# Altium schematic sheet Center Console - Connectors.SchDoc("Center Console - Connectors")
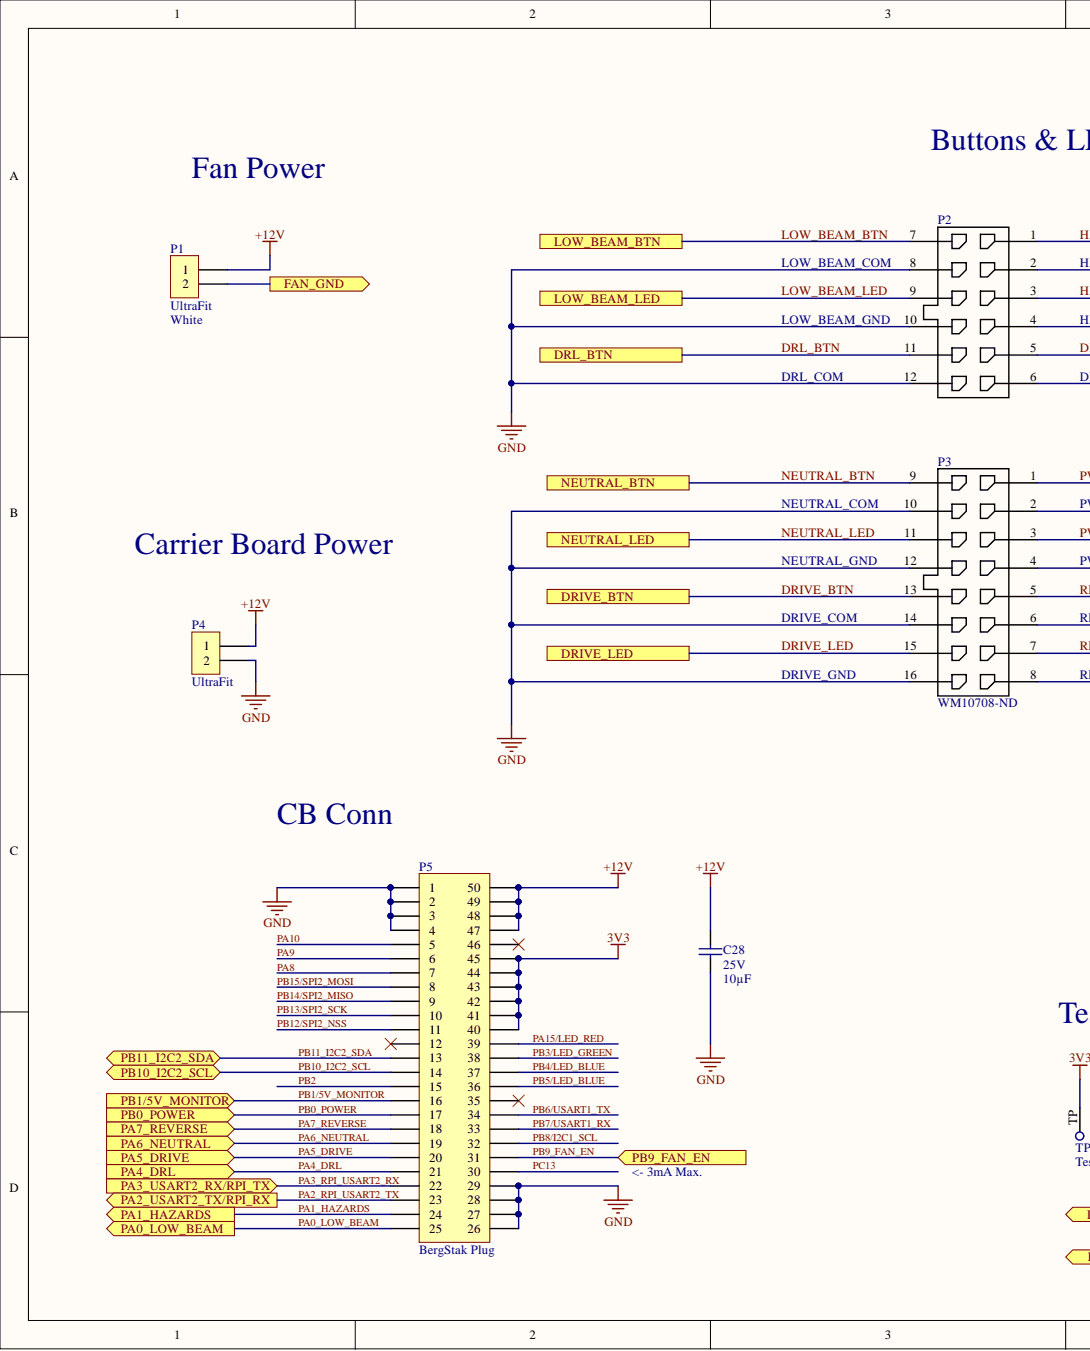
[diagram p0: Center Console - Connectors.SchDoc, left]
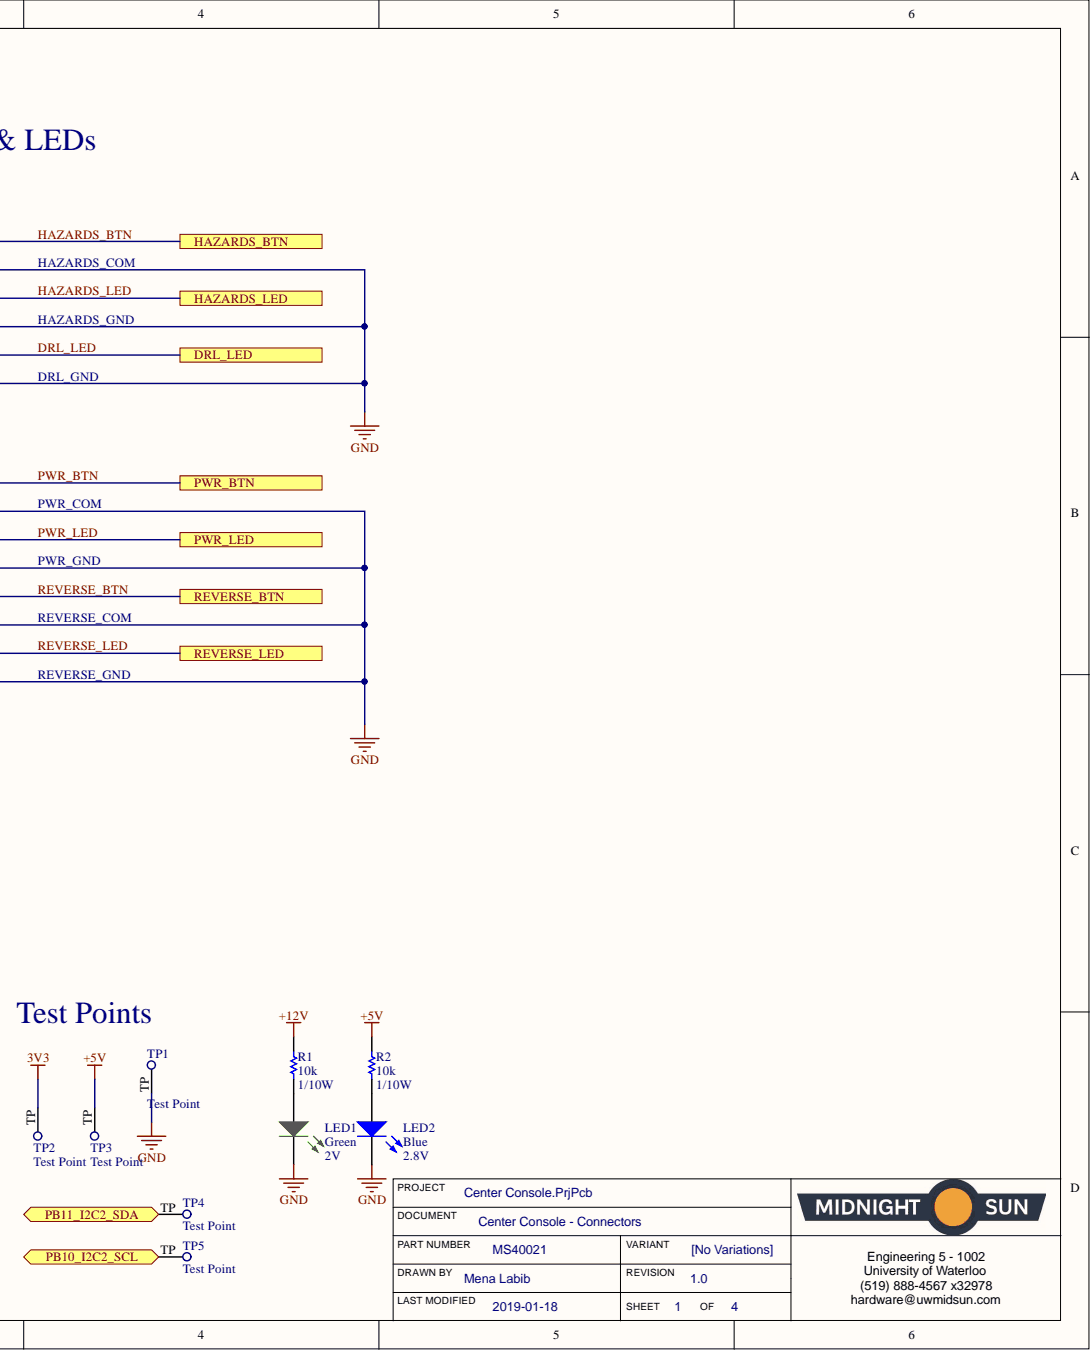
[diagram p1: Center Console - Connectors.SchDoc, right]

## Parts
C28  Voltage - Rated: 25V  Capacitance: 10µF  [CAP, 0805]  (hidden comment: CAP CER 10uF 25V 10% X5R 0805)
LED1  Voltage - Forward (Vf) (Typ): 2V  Color: Green  [LED_0603]  (hidden comment: LED GREEN CLEAR 2V 0603)
LED2  Voltage - Forward (Vf) (Typ): 2.8V  Color: Blue  [LED_0603]  (hidden comment: LED BLUE CLEAR 2.8V 0603)
P1  Series: UltraFit  Color: White  [CONN, 2POS ULTRA-FIT - NATURAL COLOR]  (hidden comment: CONN 2POS ULTRA-FIT NATURAL COLOR 0.138")
P2  CONN 12POS MICRO-FIT 3mm  [CONN, 12POS MICRO-FIT 3mm]  (value not drawn)
P3  Supplier Part Number 1: WM10708-ND  [CONN, 16POS MICRO-FIT 3MM]  (hidden comment: CONN 16POS MICRO-FIT 3mm)
P4  Series: UltraFit  [CONN, 2POS ULTRA-FIT]  (hidden comment: CONN 2POS ULTRA-FIT 0.138")
P5  Series: BergStak Plug  [CONN, Bergstak 50 Pos Plug]  (hidden comment: CONN 50POS Bergstak Plug 0.02")
R1  Resistance: 10k  Power: 1/10W  [RES, 0603]  (hidden comment: RES 10K OHM 1% 1/10W 0603)
R2  Resistance: 10k  Power: 1/10W  [RES, 0603]  (hidden comment: RES 10K OHM 1% 1/10W 0603)
TP1  Test Point  [Test Point]  (no BOM)
TP2  Test Point  [Test Point]  (no BOM)
TP3  Test Point  [Test Point]  (no BOM)
TP4  Test Point  [Test Point]  (no BOM)
TP5  Test Point  [Test Point]  (no BOM)

## Ports
DRIVE_BTN, DRIVE_LED, DRL_BTN, DRL_LED, FAN_GND, HAZARDS_BTN, HAZARDS_LED, LOW_BEAM_BTN, LOW_BEAM_LED, NEUTRAL_BTN, NEUTRAL_LED, PA0_LOW_BEAM, PA1_HAZARDS, PA2_USART2_TX/RPI_RX, PA3_USART2_RX/RPI_TX, PA4_DRL, PA5_DRIVE, PA6_NEUTRAL, PA7_REVERSE, PB0_POWER, PB1/5V_MONITOR, PB9_FAN_EN, PB10_I2C2_SCL, PB11_I2C2_SDA, PWR_BTN, PWR_LED, REVERSE_BTN, REVERSE_LED

## Nets
3V3: P5.40 P5.41 P5.42 P5.43 P5.44 P5.45 TP2.TP
+5V: R2.1 TP3.TP
+12V: C28.1 P1.1 P4.1 P5.47 P5.48 P5.49 P5.50 R1.1
GND: C28.2 LED1.2 LED2.2 P2.2 P2.4 P2.6 P2.8 P2.10 P2.12 P3.2 P3.4 P3.6 P3.8 P3.10 P3.12 P3.14 P3.16 P4.2 P5.1 P5.2 P5.3 P5.4 P5.26 P5.27 P5.28 P5.29 TP1.TP
DRIVE_BTN: P3.13 ; port DRIVE_BTN
DRIVE_LED: P3.15 ; port DRIVE_LED
DRL_BTN: P2.11 ; port DRL_BTN
DRL_LED: P2.5 ; port DRL_LED
FAN_GND: P1.2 ; port FAN_GND
HAZARDS_BTN: P2.1 ; port HAZARDS_BTN
HAZARDS_LED: P2.3 ; port HAZARDS_LED
LOW_BEAM_BTN: P2.7 ; port LOW_BEAM_BTN
LOW_BEAM_LED: P2.9 ; port LOW_BEAM_LED
NEUTRAL_BTN: P3.9 ; port NEUTRAL_BTN
NEUTRAL_LED: P3.11 ; port NEUTRAL_LED
PA0_LOW_BEAM: P5.25 ; port PA0_LOW_BEAM
PA1_HAZARDS: P5.24 ; port PA1_HAZARDS
PA2_RPI_USART2_TX: P5.23 ; port PA2_USART2_TX/RPI_RX
PA3_RPI_USART2_RX: P5.22 ; port PA3_USART2_RX/RPI_TX
PA4_DRL: P5.21 ; port PA4_DRL
PA5_DRIVE: P5.20 ; port PA5_DRIVE
PA6_NEUTRAL: P5.19 ; port PA6_NEUTRAL
PA7_REVERSE: P5.18 ; port PA7_REVERSE
PA8_1: P5.7  [also labelled PA8]
PA9_1: P5.6  [also labelled PA9]
PA10_1: P5.5  [also labelled PA10]
PA15/LED_RED_1: P5.39  [also labelled PA15/LED_RED]
PB0_POWER: P5.17 ; port PB0_POWER
PB1/5V_MONITOR: P5.16 ; port PB1/5V_MONITOR
PB2_1: P5.15  [also labelled PB2]
PB3/LED_GREEN_1: P5.38  [also labelled PB3/LED_GREEN]
PB4/LED_BLUE_1: P5.37  [also labelled PB4/LED_BLUE]
PB5/LED_BLUE_1: P5.36  [also labelled PB5/LED_BLUE]
PB6/USART1_TX_1: P5.34  [also labelled PB6/USART1_TX]
PB7/USART1_RX_1: P5.33  [also labelled PB7/USART1_RX]
PB8/I2C1_SCL_1: P5.32  [also labelled PB8/I2C1_SCL]
PB9_FAN_EN: P5.31 ; port PB9_FAN_EN
PB10_I2C2_SCL: P5.14 TP5.TP ; port PB10_I2C2_SCL
PB11_I2C2_SDA: P5.13 TP4.TP ; port PB11_I2C2_SDA
PB12/SPI2_NSS_1: P5.11  [also labelled PB12/SPI2_NSS]
PB13/SPI2_SCK_1: P5.10  [also labelled PB13/SPI2_SCK]
PB14/SPI2_MISO_1: P5.9  [also labelled PB14/SPI2_MISO]
PB15/SPI2_MOSI_1: P5.8  [also labelled PB15/SPI2_MOSI]
PC13_1: P5.30  [also labelled PC13]
PWR_BTN: P3.1 ; port PWR_BTN
PWR_LED: P3.3 ; port PWR_LED
REVERSE_BTN: P3.5 ; port REVERSE_BTN
REVERSE_LED: P3.7 ; port REVERSE_LED
NetLED1_1: LED1.1 R1.2
NetLED2_1: LED2.1 R2.2
NetP5_12: P5.12
NetP5_35: P5.35
NetP5_46: P5.46

## Library data of the parts
C28 = CAP CER 10uF 25V 10% X5R 0805: 10µF ±10% 25V Ceramic Capacitor X5R 0805 (2012 Metric); Manufacturer Part Number: GRM21BR61E106KA73L; Manufacturer: Murata Electronics North America; Tolerance: ±10%; Thickness (Max): 0.053" (1.35mm); Temperature Coefficient: X5R; Supplier Part Number 1: 490-5523-1-ND; Supplier 1: Digi-Key; Stock 1: 1695157; Size / Dimension: 0.079" L x 0.049" W (2.00mm x 1.25mm); RoHS: RoHS Compliant; Ratings: -; Pricing 1: 1=0.3, 10=0.214, 100=0.1008, 500=0.07068, 1000=0.05851 (CAD); Part Status: Active; Packaging: Cut Tape (CT); Package / Case: 0805 (2012 Metric); Operating Temperature: -55°C ~ 85°C; Mounting Type: Surface Mount, MLCC; Lead Style: -; Lead Spacing: -; Height - Seated (Max): -; Features: -; ComponentLink1URL: http://search.murata.co.jp/Ceramy/image/img/A01X/G101/ENG/GRM21BR61E106KA73-01.pdf; ComponentLink1Description: http://search.murata.co.jp/Ceramy/image/img/A01X/G101/ENG/GRM21BR61E106KA73-01.pdf; Component Kind: Standard; Category: Capacitors; Applications: General Purpose
LED1 = LED GREEN CLEAR 2V 0603: Green 572nm LED Indication - Discrete 2V 0603 (1608 Metric); Manufacturer Part Number: 150060VS75000; Manufacturer: Wurth Electronics Inc.; Wavelength - Dominant: 570nm; Viewing Angle: 140°; Millicandela Rating: 40mcd; Lens Style/Size: Rectangle with Flat Top, 1.10mm x 0.70mm; Lens Color: Colorless; Current - Test: 20mA; Wavelength - Peak: 572nm; Supplier Part Number 1: 732-4980-1-ND; Supplier Device Package: 0603; Supplier 1: Digi-Key; Stock 1: 9408; Size / Dimension: 1.60mm L x 0.80mm W; RoHS: RoHS Compliant; Pricing 1: 1=0.21, 100=0.1617, 500=0.13964 (CAD); Part Status: Active; Packaging: Cut Tape (CT); Package / Case: 0603 (1608 Metric); Mounting Type: Surface Mount; Lens Transparency: Clear; Height (Max): 0.80mm; Features: -; ComponentLink1URL: http://katalog.we-online.de/led/datasheet/150060VS75000.pdf; ComponentLink1Description: http://katalog.we-online.de/led/datasheet/150060VS75000.pdf; Component Kind: Standard; Category: Optoelectronics; Library Name: Schematic Diagrams.SchLib
LED2 = LED BLUE CLEAR 2.8V 0603: Blue 470nm LED Indication - Discrete 2.8V 0603 (1608 Metric); Manufacturer Part Number 1: LTST-C193TBKT-5A; Manufacturer 1: Lite-On Inc.; Wavelength - Peak: 468nm; Viewing Angle: 130°; Millicandela Rating: 15mcd; Lens Style/Size: Rectangle with Flat Top, 1.15mm x 0.80mm; Lens Color: Colorless; Current - Test: 5mA; Wavelength - Dominant: 470nm; Supplier Part Number 1: 160-1827-1-ND; Supplier Device Package: Chip LED; Supplier 1: Digi-Key; Size / Dimension: 1.60mm L x 0.80mm W; Part Status: Active; Packaging: Cut Tape (CT); Package / Case: 0603 (1608 Metric); Mounting Type: Surface Mount; Lens Transparency: Clear; Height (Max): 0.45mm; ComponentLink1URL: http://optoelectronics.liteon.com/upload/download/DS22-2004-060/P_100_LTST-C193TBKT-5A.pdf; ComponentLink1Description: http://optoelectronics.liteon.com/upload/download/DS22-2004-060/P_100_LTST-C193TBKT-5A.pdf; Component Kind: Standard; Library Name: Schematic Diagrams.SchLib
P1 = CONN 2POS ULTRA-FIT NATURAL COLOR 0.138": 2 Positions Header, Shrouded Connector 0.138" (3.50mm) Through Hole; Manufacturer Part Number 1: 1722872102; Manufacturer 1: Molex, LLC; Voltage: 400V; Style: Board to Cable/Wire; Shrouding: Shrouded - 4 Wall; Number of Positions Loaded: All; Number of Positions: 2; Material Flammability Rating: UL94 V-0; Mated Stacking Heights: 26.99mm; Contact Length - Post: 0.126" (3.20mm); Termination: Solder; Supplier Part Number 1: WM11722-ND; Supplier 1: Digi-Key; Row Spacing - Mating: -; Pitch - Mating: 0.138" (3.50mm); Part Status: Active; Packaging: Tray; Overall Contact Length: -; Operating Temperature: -40°C ~ 105°C; Number of Rows: 1; Mounting Type: Through Hole; Insulation Material: Liquid Crystal Polymer (LCP); Insulation Height: 0.465" (11.80mm); Insulation Color: Natural; Ingress Protection: -; Fastening Type: Latch Holder; Current Rating: -; Contact Type: Male Pin; Contact Shape: Square; Contact Material: Copper Alloy; Contact Length - Mating: -; Contact Finish Thickness - Post: 60µin (1.52µm); Contact Finish Thickness - Mating: 60µin (1.52µm); Contact Finish - Post: Tin; Contact Finish - Mating: Tin; Connector Type: Header; ComponentLink1URL: http://www.molex.com/pdm_docs/sd/1722872102_sd.pdf; ComponentLink1Description: http://www.molex.com/pdm_docs/sd/1722872102_sd.pdf; Component Kind: Standard; Library Name: Schematic Diagrams.SchLib
P2 = CONN 12POS MICRO-FIT 3mm: Connector Header Through Hole 12 position 0.118" (3.00mm); Manufacturer Part Number: 0430451227; Manufacturer: Molex, LLC; Style: Board to Cable/Wire; Shrouding: Shrouded - 4 Wall; Number of Positions Loaded: All; Number of Positions: 12; Material Flammability Rating: UL94 V-0; Contact Length - Post: 0.125" (3.18mm); Voltage Rating: -; Termination: Solder; Supplier Part Number 1: WM10697-ND; Supplier 1: Digi-Key; Stock 1: 1709; Row Spacing - Mating: 0.118" (3.00mm); RoHS: RoHS Compliant; Pricing 1: 1=3.61, 10=3.283, 25=3.0816, 50=2.9478, 100=2.8138, 250=2.5458, 500=2.34482, 1008=2.00985, 3024=1.87586 (CAD); Pitch - Mating: 0.118" (3.00mm); Part Status: Active; Packaging: Tray; Overall Contact Length: -; Operating Temperature: -; Number of Rows: 2; Mounting Type: Through Hole; Mated Stacking Heights: -; Insulation Material: Liquid Crystal Polymer (LCP), Glass Filled; Insulation Height: 0.390" (9.91mm); Insulation Color: Black; Ingress Protection: -; Features: Board Guide; Fastening Type: Locking Ramp; Current Rating: -; Contact Type: Male Pin; Contact Shape: Square; Contact Material: Brass; Contact Length - Mating: -; Contact Finish Thickness - Post: -; Contact Finish Thickness - Mating: 60.0µin (1.52µm); Contact Finish - Post: Tin; Contact Finish - Mating: Tin; Connector Type: Header; ComponentLink1URL: https://www.molex.com/pdm_docs/sd/430451227_sd.pdf; ComponentLink1Description: https://www.molex.com/pdm_docs/sd/430451227_sd.pdf; Component Kind: Standard; Category: Connectors, Interconnects; Applications: General Purpose, Medical, Military, Telecommunications; Library Name: Schematic Diagrams.SchLib
P3 = CONN 16POS MICRO-FIT 3mm: Connector Header Through Hole 16 position 0.118"(3.00mm); Manufacturer Part Number: 0430451627; Manufacturer: Molex; Row Spacing: 0.118inch; Number of Positions: 16; Number of Ports: 1; Contact Resistance: 10mR; Connector Type: Male Pin; Termination: Solder; Supplier 1: Digi-Key; Stock 1: 4654; RoHS: Yes; Pricing 1: 1 = 3.26000, 10 = 3.12900, 25 = 2.86800, 50 = 2.73780, 100 = 2.60740, 250 = 2.28148, 792 = 2.02073, 1584 = 1.82518, 3168 = 1.75999 (USD); Pitch: 3mm; Packaging: Tray; Package Quantity: 792; Orientation: Straight; Number of Terminals: 16; Number of Rows: 2; Number of Contacts: 16; Mount: Through Hole; Min Operating Temperature: -40°C; Max Operating Temperature: 105°C; Length: 28.15mm; Housing Material: Polymer; Housing Color: Black; Fastening Type: Ramp; Depth: 8.77mm; Contact Plating: Tin; Contact Material: Brass; ComponentLink2URL: http://www.molex.com/webdocs/datasheets/pdf/en-us/0430451627_PCB_HEADERS.pdf; ComponentLink2Description: http://www.molex.com/webdocs/datasheets/pdf/en-us/0430451627_PCB_HEADERS.pdf; ComponentLink1URL: http://www.molex.com/pdm_docs/sd/430451627_sd.pdf; ComponentLink1Description: http://www.molex.com/pdm_docs/sd/430451627_sd.pdf; Component Kind: Standard; Color: Black; Library Name: Schematic Diagrams.SchLib
P4 = CONN 2POS ULTRA-FIT 0.138": 2 Positions Header, Shrouded Connector 0.138" (3.50mm) Through Hole Gold; Manufacturer Part Number 1: 1722861302; Manufacturer 1: Molex, LLC; Voltage: 400V; Style: Board to Board or Cable; Shrouding: Shrouded - 4 Wall; Number of Positions Loaded: All; Number of Positions: 2; Material Flammability Rating: UL94 V-0; Mated Stacking Heights: 26.99mm; Contact Length - Post: 0.126" (3.20mm); Termination: Kinked Pin, Solder; Supplier Part Number 1: WM11673-ND; Supplier 1: Digi-Key; Row Spacing - Mating: -; Pitch - Mating: 0.138" (3.50mm); Part Status: Active; Packaging: Tray; Overall Contact Length: -; Operating Temperature: -40°C ~ 120°C; Number of Rows: 1; Mounting Type: Through Hole; Insulation Material: Liquid Crystal Polymer (LCP); Insulation Height: 0.465" (11.80mm); Insulation Color: Black; Ingress Protection: -; Fastening Type: Latch Holder; Current Rating: -; Contact Type: Male Pin; Contact Shape: Square; Contact Material: Copper Alloy; Contact Length - Mating: -; Contact Finish Thickness - Post: 60µin (1.52µm); Contact Finish Thickness - Mating: 30µin (0.76µm); Contact Finish - Post: Tin; Contact Finish - Mating: Gold; Connector Type: Header; ComponentLink1URL: http://www.molex.com/pdm_docs/sd/1722861302_sd.pdf; ComponentLink1Description: http://www.molex.com/pdm_docs/sd/1722861302_sd.pdf; Component Kind: Standard; Library Name: Schematic Diagrams.SchLib
P5 = CONN 50POS Bergstak Plug 0.02": 50 Position Connector Plug, Outer Shroud Contacts Surface Mount Gold; Manufacturer Part Number 1: 10132797-055100LF; Manufacturer 1: Amphenol FCI; Pitch: 0.020" (0.50mm); Number of Positions: 50; Mated Stacking Heights: 5mm; Supplier Part Number 1: 609-5226-1-ND; Supplier 1: Digi-Key; Part Status: Active; Packaging: Cut Tape (CT); Number of Rows: 2; Mounting Type: Surface Mount; Height Above Board: 0.169" (4.30mm); Contact Finish Thickness: 1µin (0.025µm); Contact Finish: Gold; Connector Type: Plug, Outer Shroud Contacts; ComponentLink1URL: http://portal.fciconnect.com/Comergent//fci/drawing/10132797.pdf; ComponentLink1Description: http://portal.fciconnect.com/Comergent//fci/drawing/10132797.pdf; Component Kind: Standard; Library Name: Schematic Diagrams.SchLib
R1 R2 = RES 10K OHM 1% 1/10W 0603: RES SMD 10K OHM 1% 1/10W 0603; Manufacturer Part Number 1: RC0603FR-0710KL; Manufacturer 1: Yageo; Temperature Coefficient: ±100ppm/°C; Tolerance: ±1%; Supplier Part Number 1: 311-10.0KHRCT-ND; Supplier Device Package: 0603; Supplier 1: Digi-Key; Size / Dimension: 0.063" L x 0.031" W (1.60mm x 0.80mm); Part Status: Active; Packaging: Cut Tape (CT); Package / Case: 0603 (1608 Metric); Operating Temperature: -55°C ~ 155°C; Height - Seated (Max): 0.022" (0.55mm); Failure Rate: -; Composition: Thick Film; ComponentLink1URL: http://www.yageo.com/documents/recent/PYu-RC_Group_51_RoHS_L_7.pdf; ComponentLink1Description: http://www.yageo.com/documents/recent/PYu-RC_Group_51_RoHS_L_7.pdf; Component Kind: Standard; Library Name: Schematic Diagrams.SchLib
TP1-TP5 = Test Point: Library Name: Schematic Diagrams.SchLib
